# Altium schematic sheet PIC32MX.SchDoc
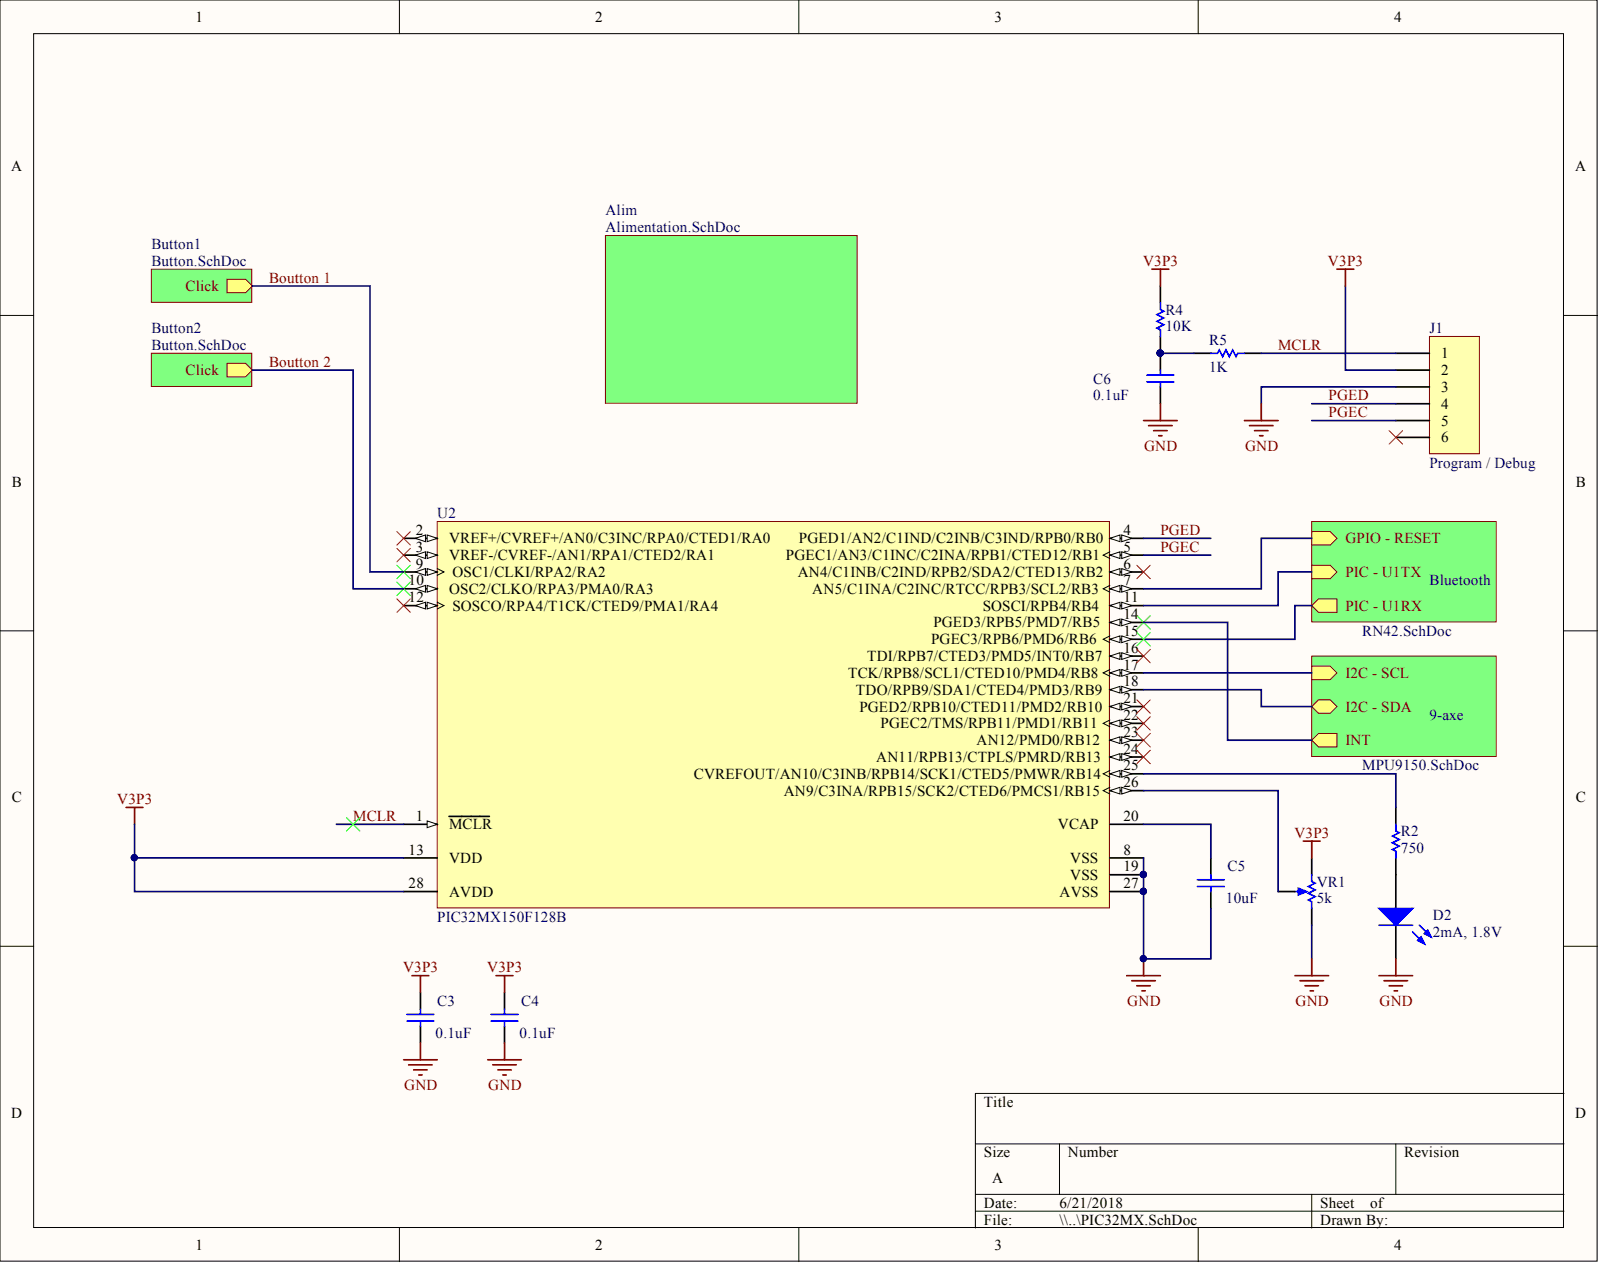
[diagram p0: PIC32MX.SchDoc]

## Parts
C3  0.1uF  [CAP 0603]
C4  0.1uF  [CAP 0603]
C5  10uF  [CAP 0603]
C6  0.1uF  [CAP 0603]
D2  2mA, 1.8V  [LED]
J1  Program / Debug  [ICSP]
R2  750  [RES 0603]
R4  10K  [RES 0603]
R5  1K  [RES 0603]
U2  PIC32MX150F128B  [PIC32MX150F128B]  (value not drawn)
VR1  5k  [91A1A-B28-B13L]

## Nets
GND: C3.1 C4.1 C5.1 C6.1 D2.2 J1.3 U2.8(VSS) U2.19(VSS) U2.27(AVSS) VR1.2
V3P3: C3.2 C4.2 J1.2 R4.2 U2.13(VDD) U2.28(AVDD) VR1.1
BOUTTON 1: U2.9(OSC1/CLKI/RPA2/RA2)
BOUTTON 2: U2.10(OSC2/CLKO/RPA3/PMA0/RA3)
MCLR: J1.1 R5.1 U2.1(MCLR)
PGEC: J1.5 U2.5(PGEC1/AN3/C1INC/C2INA/RPB1/CTED12/RB1)
PGED: J1.4 U2.4(PGED1/AN2/C1IND/C2INB/C3IND/RPB0/RB0)
NetC5_2: C5.2 U2.20(VCAP)
NetC6_2: C6.2 R4.1 R5.2
NetD2_1: D2.1 R2.1
NetJ1_6: J1.6
NetR2_2: R2.2 U2.25(CVREFOUT/AN10/C3INB/RPB14/SCK1/CTED5/PMWR/RB14)
NetU2_2: U2.2(VREF+/CVREF+/AN0/C3INC/RPA0/CTED1/RA0)
NetU2_3: U2.3(VREF-/CVREF-/AN1/RPA1/CTED2/RA1)
NetU2_6: U2.6(AN4/C1INB/C2IND/RPB2/SDA2/CTED13/RB2)
NetU2_7: U2.7(AN5/C1INA/C2INC/RTCC/RPB3/SCL2/RB3)
NetU2_11: U2.11(SOSCI/RPB4/RB4)
NetU2_12: U2.12(SOSCO/RPA4/T1CK/CTED9/PMA1/RA4)
NetU2_14: U2.14(PGED3/RPB5/PMD7/RB5)
NetU2_15: U2.15(PGEC3/RPB6/PMD6/RB6)
NetU2_16: U2.16(TDI/RPB7/CTED3/PMD5/INT0/RB7)
NetU2_17: U2.17(TCK/RPB8/SCL1/CTED10/PMD4/RB8)
NetU2_18: U2.18(TDO/RPB9/SDA1/CTED4/PMD3/RB9)
NetU2_21: U2.21(PGED2/RPB10/CTED11/PMD2/RB10)
NetU2_22: U2.22(PGEC2/TMS/RPB11/PMD1/RB11)
NetU2_23: U2.23(AN12/PMD0/RB12)
NetU2_24: U2.24(AN11/RPB13/CTPLS/PMRD/RB13)
NetU2_26: U2.26(AN9/C3INA/RPB15/SCK2/CTED6/PMCS1/RB15) VR1.3

## Library data of the parts
C3-C6 = Cap: Capacitor; Fabricant: Murata; Fournisseur: Farnell; Component Kind: Standard; Library Name: Miscellaneous Devices.IntLib; PackageDescription: Radial Cap, Thru-Hole; 2 Leads; 0.3 in Pin Spacing; PackageReference: RAD-0.3; Signal Integrity: Cap; Simulation: CAP
  C3 C4 C6: Value: 0.1uF
  C5: Value: 10uF
D2 = LED0: Typical INFRARED GaAs LED; Fabricant: Kingbright; Fournisseur: Farnell; RefFabricant: KPT-2012LVSECK-J3-PRV; RefFournisseur: 2610416; Component Kind: Standard; Library Name: Miscellaneous Devices.IntLib; PackageDescription: LED; 2 Leads; PackageReference: LED-0; Simulation: LED0; Value: 2mA, 1.8V
J1 = MHDR1X6: Header, 6-Pin; Fabricant: AMP - TE CONNECTIVITY; Fournisseur: Farnell; RefFabricant: 826629-6; RefFournisseur: 3418327; Component Kind: Standard; Library Name: Miscellaneous Connectors.IntLib; PackageDescription: Debug Interface; Signal Integrity: Connector
R2 = Res1: Resistor; Fabricant: Multicomp; Fournisseur: Farnell; RefFournisseur: 9331506; Component Kind: Standard; Library Name: Miscellaneous Devices.IntLib; PackageDescription: Axial Device, Thru-Hole; 2 Leads; 0.3 in Pin Spacing; PackageReference: AXIAL-0.3; Signal Integrity: Res; Simulation: RESISTOR; Value: 750
R4 = Res1: Resistor; Fabricant: Multicomp; Fournisseur: Farnell; RefFournisseur: 9330399; Component Kind: Standard; Library Name: Miscellaneous Devices.IntLib; PackageDescription: Axial Device, Thru-Hole; 2 Leads; 0.3 in Pin Spacing; PackageReference: AXIAL-0.3; Signal Integrity: Res; Simulation: RESISTOR; Value: 10K
R5 = Res1: Resistor; Fabricant: Multicomp; Fournisseur: Farnell; RefFournisseur: 9330380; Component Kind: Standard; Library Name: Miscellaneous Devices.IntLib; PackageDescription: Axial Device, Thru-Hole; 2 Leads; 0.3 in Pin Spacing; PackageReference: AXIAL-0.3; Signal Integrity: Res; Simulation: RESISTOR; Value: 1K
U2 = PIC32MX130F256B: PIC32MX Family Microcontroller; Fabricant: Microchip; Fournisseur: Farnell; RefFabricant: PIC32MX150F128B-I/SO; RefFournisseur: 2313766; Component Kind: Standard; Library Name: Schematics_Library.SchLib; PackageDescription: Pic32; PackageReference: SOIC
VR1 = RPot: Potentiometer; Fabricant: Bourns; Fournisseur: Farnell; RefFournisseur: 9357750; Set Position: 0.5; Component Kind: Standard; Library Name: Miscellaneous Devices.IntLib; PackageDescription: Variable Resistor, Thru-Hole; 3 Leads; PackageReference: VR5; RefFabricant: 91A1A-B28-B13L; Simulation: POT; Value: 5K
